# Altium schematic sheet MCU.SchDoc
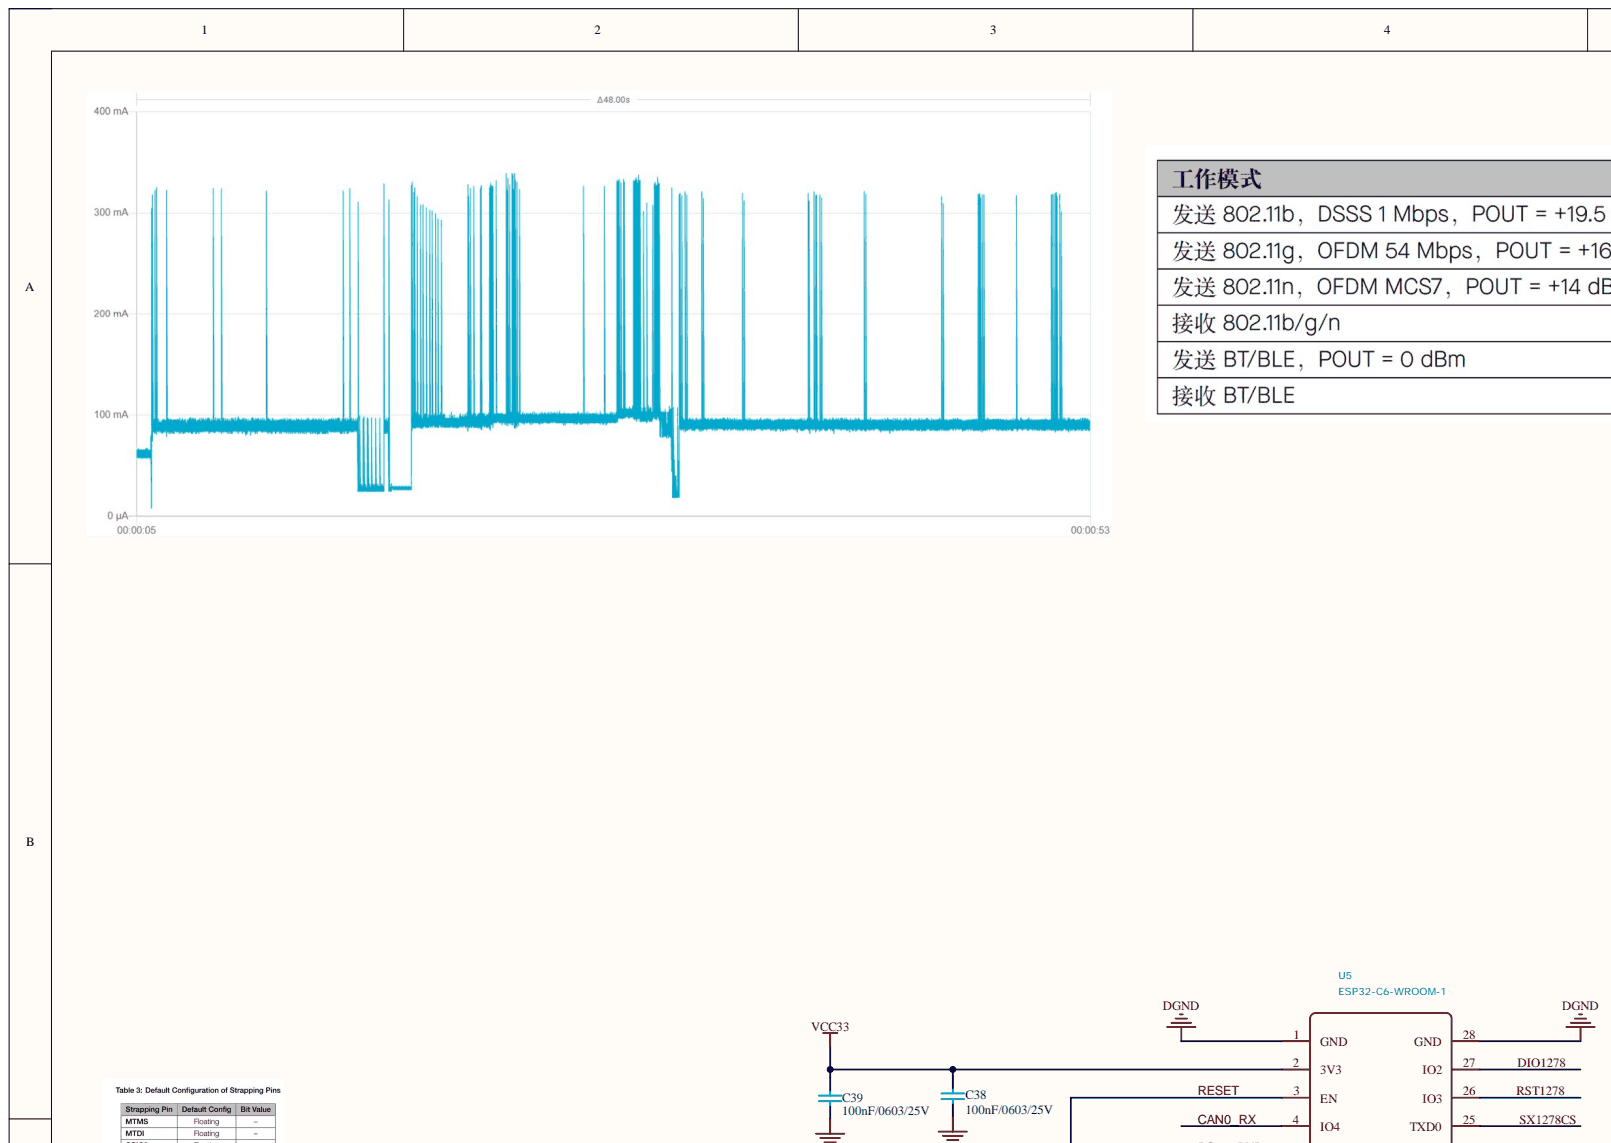
[diagram p0: MCU.SchDoc, top left]
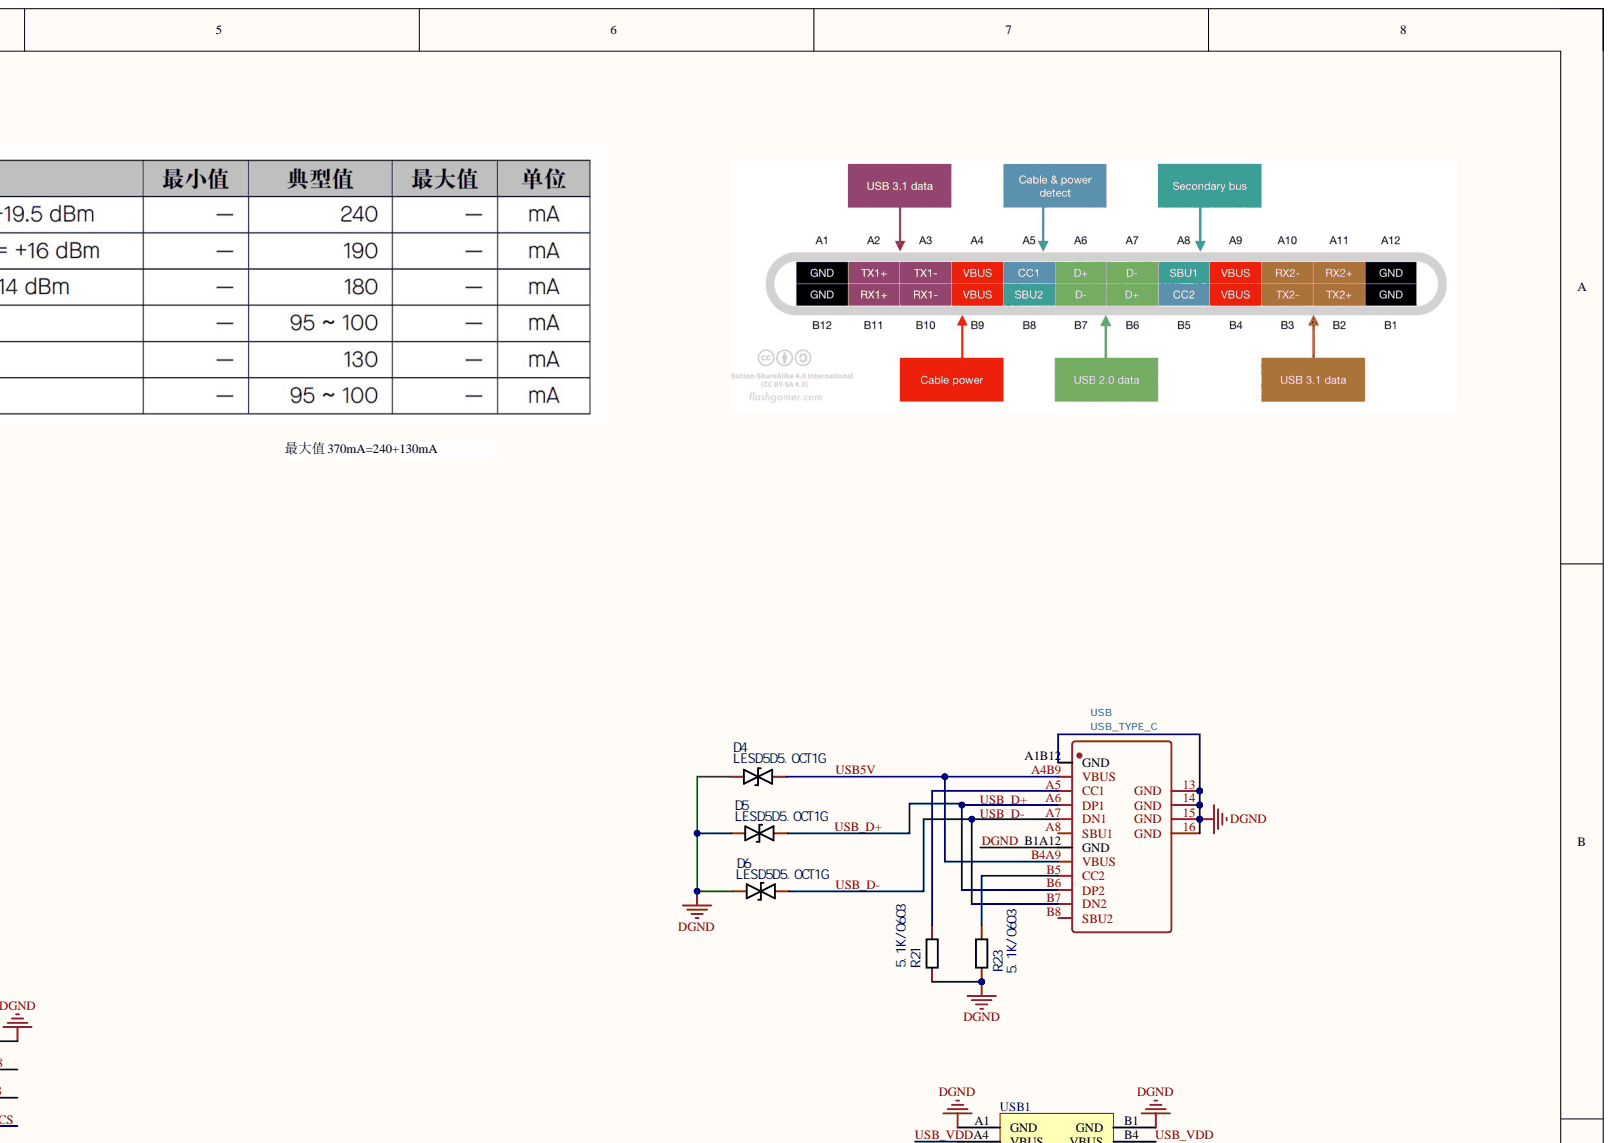
[diagram p1: MCU.SchDoc, top right]
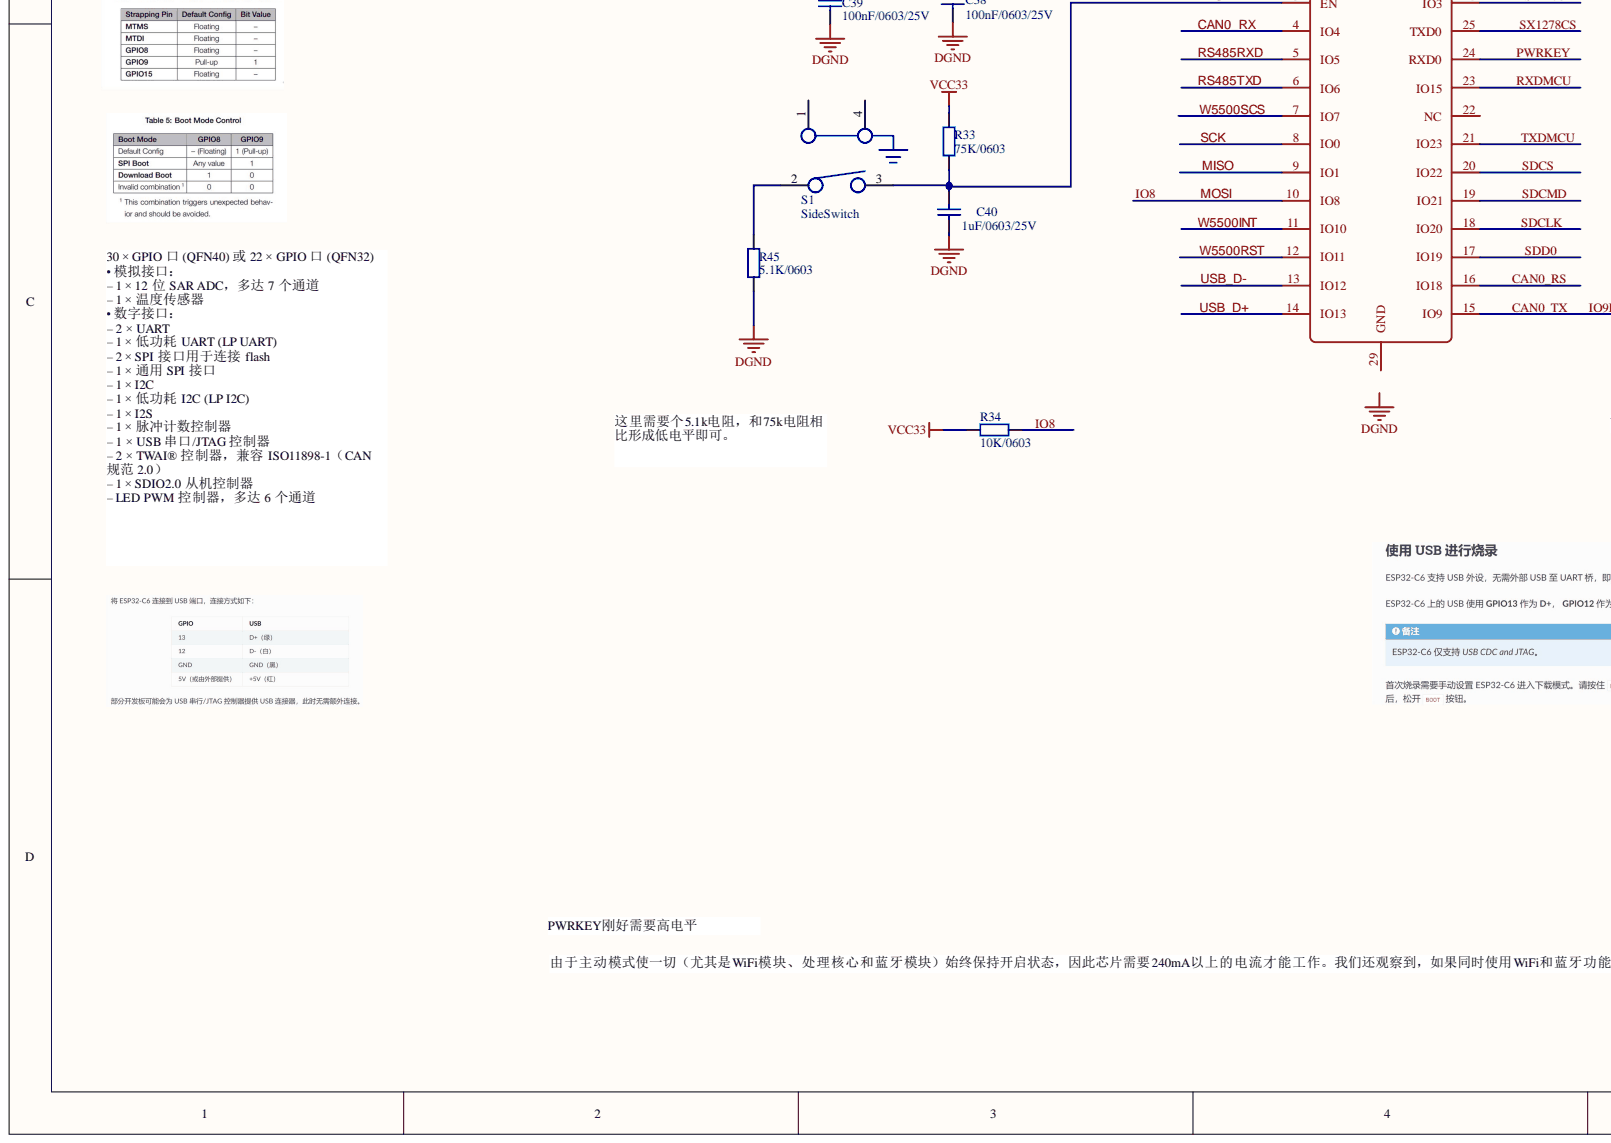
[diagram p2: MCU.SchDoc, bottom left]
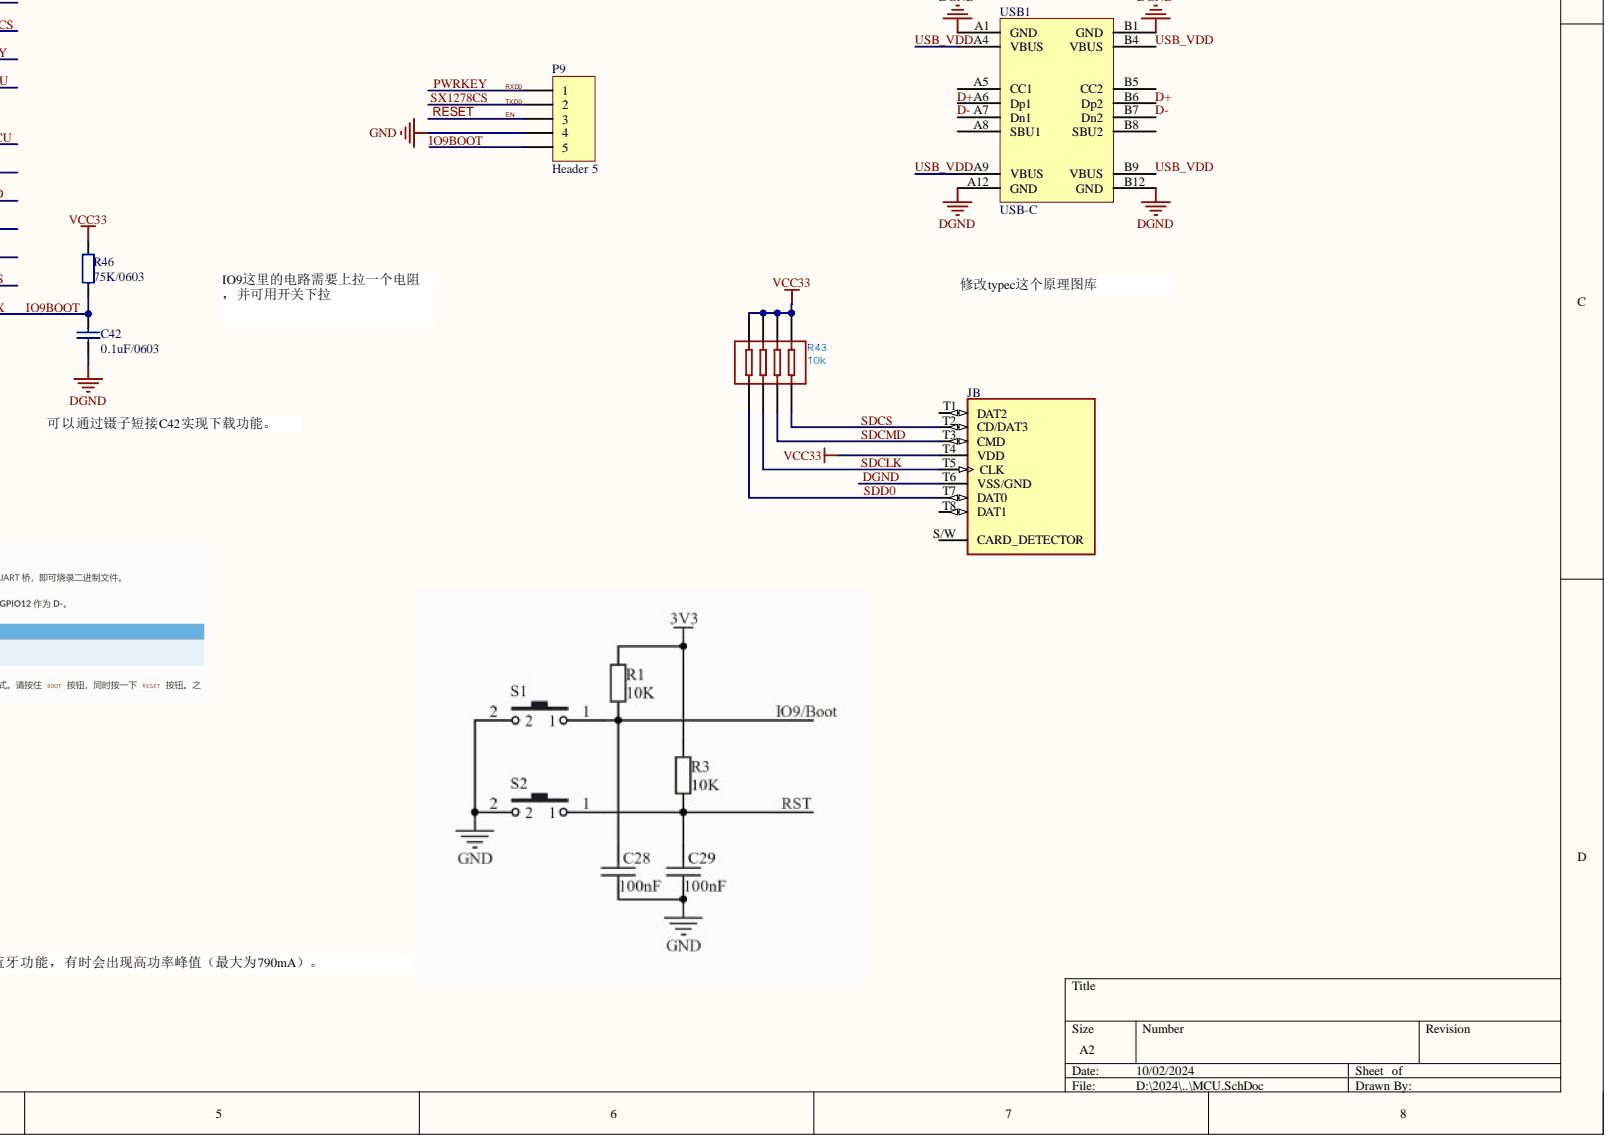
[diagram p3: MCU.SchDoc, bottom right]

## Parts
C38  100nF/0603/25V  [CAP0603]
C39  100nF/0603/25V  [CAP0603]
C40  1uF/0603/25V  [CAP0603]
C42  0.1uF/0603  [CAP0603]
D4  LESD5D5.0CT1G  [LESD5D5.0CT1G-SOD-523]
D5  LESD5D5.0CT1G  [LESD5D5.0CT1G-SOD-523]
D6  LESD5D5.0CT1G  [LESD5D5.0CT1G-SOD-523]
J  104642-1610  [MOLEX_104642-1610]  (value not drawn)  (part B)
P9  Header 5  [HDR1X5]
R21  5.1K/0603  [RES0603]
R23  5.1K/0603  [RES0603]
R33  75K/0603  [R0603]
R34  10K/0603  [R0603]
R43  10k  [RES-ARRAY-SMD_8P-L3.2-W1.6-P0.80-BL]
R45  5.1K/0603  [R0603]
R46  75K/0603  [R0603]
S1  SideSwitch  [SideSwitch]
U5  ESP32-C6-WROOM-1  [ESP32C6WROOM1N4]
USB  USB_TYPE_C  [USB-C-SMD_TYPEC-304J-BCP16]
USB1  USB-C  [USB Type-C-16Pin_A]

## Nets
GND: P9.4
VCC33: C38.1 C39.1 J.T4(VDD) R33.1 R34.1 R43.5 R43.6 R43.7 R43.8 R46.1 U5.2(3V3)
CAN0_RS: U5.16(IO18)
CAN0_RX: U5.4(IO4)
CAN0_TX: C42.1 P9.5 R46.2 U5.15(IO9)
D+: USB1.A6(Dp1) USB1.B6(Dp2)
D-: USB1.A7(Dn1) USB1.B7(Dn2)
DGND: C38.2 C39.2 C40.1 C42.2 D4.2 D5.2 D6.2 J.T6(VSS/GND) R21.1 R23.1 R45.1 U5.1(GND) U5.28(GND) USB.13(GND) USB.14(GND) USB.15(GND) USB.16(GND) USB.A1B12(GND) USB.B1A12(GND) USB1.A1(GND) USB1.A12(GND) USB1.B1(GND) USB1.B12(GND)
DIO1278: U5.27(IO2)
EN: C40.2 P9.3 R33.2 S1.3 U5.3(EN)
IO8: R34.2 U5.10(IO8)
MISO: U5.9(IO1)
PWRKEY: P9.1 U5.24(RXD0)
RS485RXD: U5.5(IO5)
RS485TXD: U5.6(IO6)
RST1278: U5.26(IO3)
RXDMCU: U5.23(IO15)
SCK: U5.8(IO0)
SDCLK: J.T5(CLK) R43.3 U5.18(IO20)
SDCMD: J.T3(CMD) R43.2 U5.19(IO21)
SDCS: J.T2(CD/DAT3) R43.1 U5.20(IO22)
SDD0: J.T7(DAT0) R43.4 U5.17(IO19)
SX1278CS: P9.2 U5.25(TXD0)
TXDMCU: U5.21(IO23)
USB5V: D4.1 USB.A4B9(VBUS) USB.B4A9(VBUS)
USB_D+: D5.1 U5.14(IO13) USB.A6(DP1) USB.B6(DP2)
USB_D-: D6.1 U5.13(IO12) USB.A7(DN1) USB.B7(DN2)
USB_VDD: USB1.A4(VBUS) USB1.A9(VBUS) USB1.B4(VBUS) USB1.B9(VBUS)
W5500INT: U5.11(IO10)
W5500RST: U5.12(IO11)
W5500SCS: U5.7(IO7)
NetR21_2: R21.2 USB.A5(CC1)
NetR23_2: R23.2 USB.B5(CC2)
NetR45_2: R45.2 S1.2

Not connected: J S/W(CARD_DETECTOR) T1(DAT2) T8(DAT1); S1 1 4; U5 22(NC) 29(GND); USB A8(SBU1) B8(SBU2); USB1 A5(CC1) A8(SBU1) B5(CC2) B8(SBU2)

## Library data of the parts
C38-C40 C42 = Cap Semi: Capacitor (Semiconductor SIM Model); Component Kind: Standard; LatestRevisionDate: 29-May-2009; LatestRevisionNote: IPC-7351 Footprint Added.; Library Name: Miscellaneous Devices.IntLib; PackageDescription: Chip Capacitor; PackageReference: C1206; Published: 8-Jun-2000; Signal Integrity: Cap Semi; Simulation: CAP; Publisher: Altium Limited
D4-D6 = LESD5D5.0CT1G: Manufacturer: Leshan Radio; Add into BOM: yes; Origin Footprint: SOD-523_L1.2-W0.8-LS1.6-BI; Component Kind: Standard; Convert to PCB: yes; Device: LESD5D5.0CT1G; JLCPCB Part Class: Extended Part; Manufacturer Part: LESD5D5.0CT1G; Name: LESD5D5.0CT1G; Supplier: LCSC; Supplier Part: C383211; Symbol: LESD5D5.0CT1G
J = 104642-1610: 17 (16 + 1) Position Card Connector Combo - microSDâ„¢, Micro SIM Surface Mount, Right Angle Gold or Gold-Palladium; MF: Molex; Availability: Warning; Component Kind: Standard; Mouser-Purchase-URL: https://snapeda.com/shop?store=Mouser&id=501052; MP: 104642-1610; Package: None; Price: None; SnapEDA_Link: https://www.snapeda.com/parts/104642-1610/Molex/view-part/501052/?ref=snap; Library Name: ESPC6IOTALLinOne.SCHLIB
P9 = Header 5: Header, 5-Pin; Component Kind: Standard; LatestRevisionDate: 17-Jul-2002; LatestRevisionNote: Re-released for DXP Platform.; Library Name: Miscellaneous Connectors.IntLib; Signal Integrity: Connector; Publisher: Altium Limited
R21 R23 = 5.1K: Manufacturer: UniOhm; Manufacturer Part: 0402WGF5101TCE; Add into BOM: yes; Origin Footprint: R0402; Component Kind: Standard; Convert to PCB: yes; Device: 5.1K; JLCPCB Part Class: Basic Part; Name: 5.1K; Supplier: LCSC; Supplier Part: C25905; Symbol: 5.1K
R33 R34 R45 R46 = Res2: Resistor; Value: 1K; Component Kind: Standard; LatestRevisionDate: 17-Jul-2002; LatestRevisionNote: Re-released for DXP Platform.; Library Name: Miscellaneous Devices.IntLib; PackageDescription: Axial Device, Thru-Hole; 2 Leads; 0.4 in Pin Spacing; PackageReference: AXIAL-0.4; Published: 8-Jun-2000; Signal Integrity: Res; Simulation: RESISTOR; Publisher: Altium Limited
R43 = 2.2K排阻-4D03: 电阻排; Component Kind: Standard
S1 = SideSwitch: Tactile Switch SPST-NO Side Actuated Through Hole, Right Angle; MF: Wurth Elektronik; MP: 431256038726; Availability: In Stock; Check_prices: https://www.snapeda.com/parts/431256038726/Wurth+Elektronik/view-part/?ref=eda; Component Kind: Standard; Package: None; Price: None; Purchase-URL: https://pricing.snapeda.com/search/part/431256038726/?ref=eda; SnapEDA_Link: https://www.snapeda.com/parts/431256038726/Wurth+Elektronik/view-part/?ref=snap; Library Name: ESPC6IOTALLinOne.SCHLIB
USB = TYPEC-304J-BCP16: usb type C 插座; Component Kind: Standard
USB1 = USB-C-16P: USB-C型母接口; 版权归属: 源创客; BOM名称: USB-C型母接口; Component Kind: Standard; Library Name: 4.1   - 接口类.SchLib; 学习资源: www.ooocke.com
U5 = ESP32-C6-WROOM-1: Component Kind: Standard
